# Altium schematic sheet Bus Management_B2.SchDoc
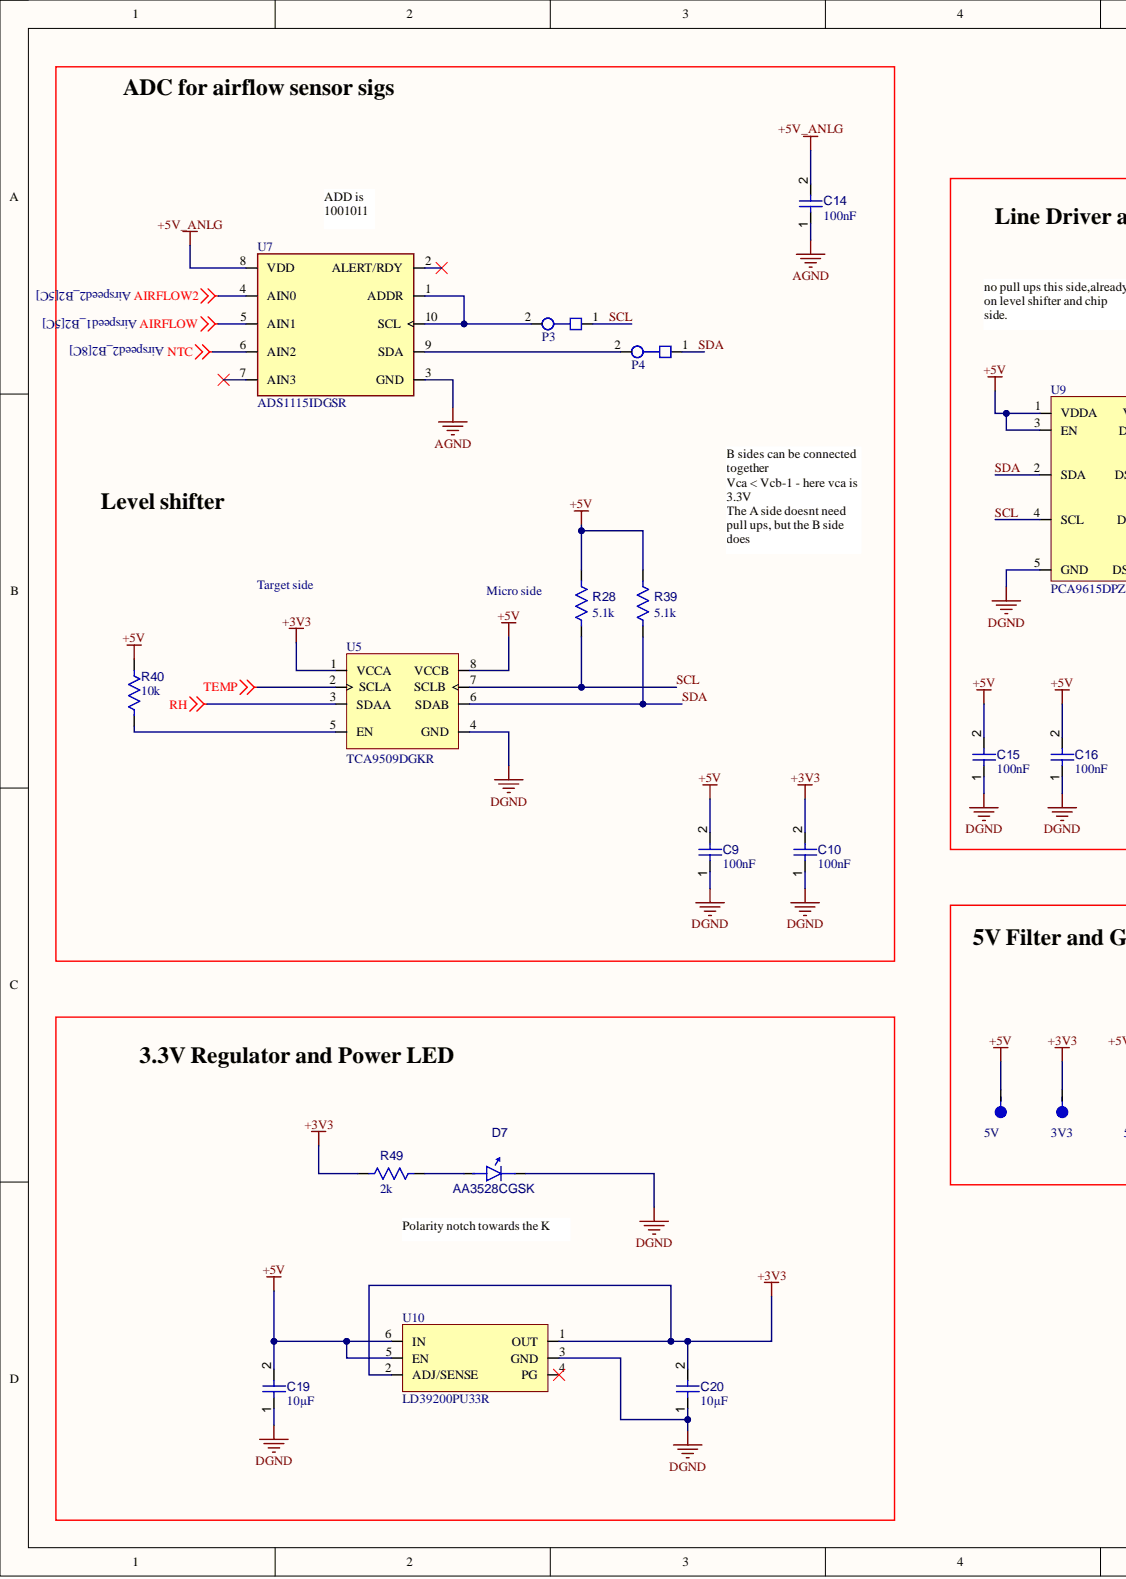
[diagram p0: Bus Management_B2.SchDoc, left]
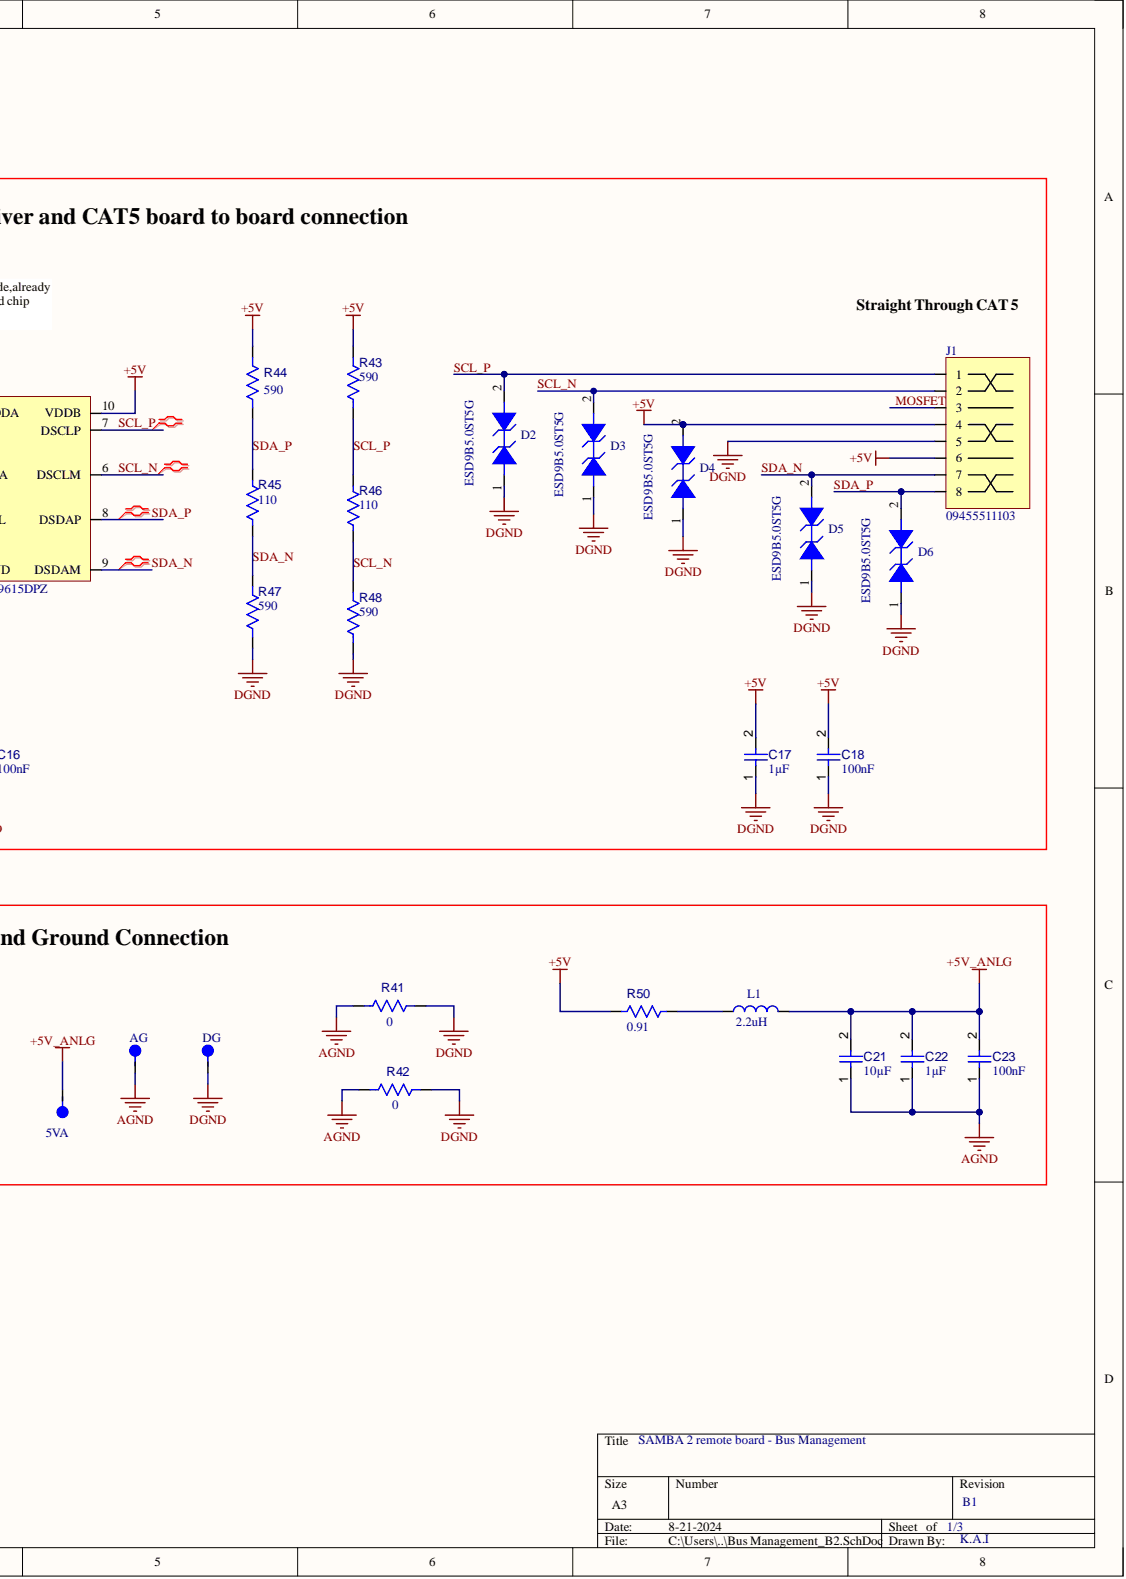
[diagram p1: Bus Management_B2.SchDoc, right]

## Parts
3V3  PH1-01-UA  [FP-PH1-01-UA-MFG]  (value not drawn)
5V  PH1-01-UA  [FP-PH1-01-UA-MFG]  (value not drawn)
5VA  PH1-01-UA  [FP-PH1-01-UA-MFG]  (value not drawn)
AG  PH1-01-UA  [FP-PH1-01-UA-MFG]  (value not drawn)
C9  value 100nF  [FP-CC0603-DA-MFG]  (hidden comment: CC0603KRX7R7BB104)
C10  value 100nF  [FP-CC0603-DA-MFG]  (hidden comment: CC0603KRX7R7BB104)
C14  value 100nF  [FP-CC0603-DA-MFG]  (hidden comment: CC0603KRX7R7BB104)
C15  value 100nF  [FP-CC0603-DA-MFG]  (hidden comment: CC0603KRX7R7BB104)
C16  value 100nF  [FP-CC0603-DA-MFG]  (hidden comment: CC0603KRX7R7BB104)
C17  value 1µF  [FP-CL10-IPC_C]  (hidden comment: CL10B105KA8NFNC)
C18  value 100nF  [FP-CC0603-DA-MFG]  (hidden comment: CC0603KRX7R7BB104)
C19  value 10µF  [FP-GRM188-0_15-MFG]  (hidden comment: GRM188R61A106ME69D)
C20  value 10µF  [FP-GRM188-0_15-MFG]  (hidden comment: GRM188R61A106ME69D)
C21  value 10µF  [FP-GRM188-0_15-MFG]  (hidden comment: GRM188R61A106ME69D)
C22  value 1µF  [FP-CL10-IPC_C]  (hidden comment: CL10B105KA8NFNC)
C23  value 100nF  [FP-CC0603-DA-MFG]  (hidden comment: CC0603KRX7R7BB104)
D2  ESD9B5.0ST5G  [ONSC-SOD-923-2-514AB-01_V]
D3  ESD9B5.0ST5G  [ONSC-SOD-923-2-514AB-01_V]
D4  ESD9B5.0ST5G  [ONSC-SOD-923-2-514AB-01_V]
D5  ESD9B5.0ST5G  [ONSC-SOD-923-2-514AB-01_V]
D6  ESD9B5.0ST5G  [ONSC-SOD-923-2-514AB-01_V]
D7  AA3528CGSK  [AA3528CGSK-Footprint-1]
DG  PH1-01-UA  [FP-PH1-01-UA-MFG]  (value not drawn)
J1  09455511103  [09455511103-Footprint-1]
L1  value 2.2uH  [INDC3225X06N]  (hidden comment: CC322522A-2R2K)
P3  PH1-02-UA  [PH1-02-UA-Footprint-1]  (value not drawn)
P4  PH1-02-UA  [PH1-02-UA-Footprint-1]  (value not drawn)
R28  value 5.1k  [0805]  (hidden comment: RC0805JR-075K1L)
R39  value 5.1k  [0805]  (hidden comment: RC0805JR-075K1L)
R40  value 10k  [FP-RC0603-0_55-MFG]  (hidden comment: RC0603FR-0710KL)
R41  value 0  [FP-RMCF0603-MFG]  (hidden comment: Resistor 0R +/- 5% 0603 100 mW)
R42  value 0  [FP-RMCF0603-MFG]  (hidden comment: Resistor 0R +/- 5% 0603 100 mW)
R43  value 590  [RESC1608X55X30LL15T15]  (hidden comment: ERJ-3EKF5900V)
R44  value 590  [RESC1608X55X30LL15T15]  (hidden comment: ERJ-3EKF5900V)
R45  value 110  [FP-RMCF0603-MFG]  (hidden comment: RMCF0603FT110R)
R46  value 110  [FP-RMCF0603-MFG]  (hidden comment: RMCF0603FT110R)
R47  value 590  [RESC1608X55X30LL15T15]  (hidden comment: ERJ-3EKF5900V)
R48  value 590  [RESC1608X55X30LL15T15]  (hidden comment: ERJ-3EKF5900V)
R49  value 2k  [IPC B 0603 RES]  (hidden comment: ERJ-3EKF2001V)
R50  value 0.91  [RESC2013X60X35ML10T20]  (hidden comment: RL0805FR-070R91L)
U5  TCA9509DGKR  [TSOP65P490X110-8N]
U7  ADS1115IDGSR  [FP-DGS0010A-MFG]
U9  PCA9615DPZ  [TSSOP50P490X110-10N]
U10  LD39200PU33R  [FP-DFN6-7946637-MFG]

## Nets
+3V3: 3V3.1 C10.2 C20.2 R49.2 U5.1(VCCA) U10.1(OUT) U10.2(ADJ/SENSE)
+5V: 5V.1 C9.2 C15.2 C16.2 C17.2 C18.2 C19.2 D4.2 J1.4 J1.6 R28.2 R39.2 R40.2 R43.2 R44.2 R50.1 U5.8(VCCB) U9.1(VDDA) U9.3(EN) U9.10(VDDB) U10.5(EN) U10.6(IN)
+5V_ANLG: 5VA.1 C14.2 C21.2 C22.2 C23.2 L1.2 U7.8(VDD)
AGND: AG.1 C14.1 C21.1 C22.1 C23.1 R41.1 R42.1 U7.3(GND)
AIRFLOW: U7.5(AIN1)
AIRFLOW2: U7.4(AIN0)
DGND: C9.1 C10.1 C15.1 C16.1 C17.1 C18.1 C19.1 C20.1 D2.1 D3.1 D4.1 D5.1 D6.1 D7.1 DG.1 J1.5 R41.2 R42.2 R47.1 R48.1 U5.4(GND) U9.5(GND) U10.3(GND)
NTC: U7.6(AIN2)
RH: U5.3(SDAA)
TEMP: U5.2(SCLA)
MOSFET: J1.3
SCL: P3.1 R28.1 U5.7(SCLB) U9.4(SCL)
SCL_N: D3.2 J1.2 R46.1 R48.2 U9.6(DSCLM)
SCL_P: D2.2 J1.1 R43.1 R46.2 U9.7(DSCLP)
SDA: P4.1 R39.1 U5.6(SDAB) U9.2(SDA)
SDA_N: D5.2 J1.7 R45.1 R47.2 U9.9(DSDAM)
SDA_P: D6.2 J1.8 R44.1 R45.2 U9.8(DSDAP)
NetD7_2: D7.2 R49.1
NetL1_1: L1.1 R50.2
NetP3_2: P3.2 U7.1(ADDR) U7.10(SCL)
NetP4_2: P4.2 U7.9(SDA)
NetR40_1: R40.1 U5.5(EN)

Not connected: U7 2(ALERT/RDY) 7(AIN3); U10 4(PG)

## Library data of the parts
3V3 5V 5VA AG DG = CMP-002-00083-1: Conn Unshrouded Header HDR 1 POS 2.54mm Solder ST Thru-Hole Bag; Component Kind: Standard; Library Name: University of Sydney
C9 C10 C14-C16 C18 C23 = CMP-001-00099-1: Ceramic Capacitor, Multilayer, Ceramic, 16V, 10% +Tol, 10% -Tol, X7R, 15% TC, 0.1uF, Surface Mount, 0603; Capacitor Type: Ceramic; Case/Package: 0603; Component Kind: Standard; Max Operating Temperature: 125°C; Min Operating Temperature: -55°C; Mounting Technology: Surface Mount; Pins: 2; RoHS Compliant: Yes; Library Name: University of Sydney
C17 C22 = CMP-001-00098-1: Ceramic Capacitor, Multilayer, Ceramic, 25V, 10% +Tol, 10% -Tol, X7R, 15% TC, 1uF, Surface Mount, 0603; Capacitor Type: Ceramic; Case/Package: 0603; Component Kind: Standard; Max Operating Temperature: 125°C; Min Operating Temperature: -55°C; Mounting Technology: Surface Mount; Pins: 2; RoHS Compliant: Yes; Library Name: University of Sydney
C19-C21 = CMP-001-00097-1: Ceramic Capacitor, Multilayer, Ceramic, 10V, 20% +Tol, 20% -Tol, X5R, 15% TC, 10uF, Surface Mount, 0603; Capacitor Type: Ceramic; Case/Package: 0603; Component Kind: Standard; Max Operating Temperature: 85°C; Min Operating Temperature: -55°C; Mounting Technology: Surface Mount; Pins: 2; RoHS Compliant: Yes; Library Name: University of Sydney
D2-D6 = CMP-004-00032-1: Trans Voltage Suppressor Diode, 5V V(RWM), Bidirectional, 1 Element, Silicon; Component Kind: Standard; Max Operating Temperature: 150°C; Min Operating Temperature: -55°C; Pins: 2; RoHS Compliant: Yes; Library Name: University of Sydney
D7 = CMP-004-00031-3: Single Color LED, Green, Water Clear, 2.4mm; Forward Current: 30mA; Colour: Green; Component Kind: Standard; Max Operating Temperature: 85°C; Min Operating Temperature: -40°C; Mounting Technology: Surface Mount; Pins: 2; RoHS Compliant: Yes; Library Name: University of Sydney
J1 = CMP-002-00071-6: Telecom and Datacom Connector, 8 Contact(s), Female, Straight, Solder Terminal, Locking, Jack; Component Kind: Standard; Connector Type: TELECOM AND DATACOM CONNECTOR; Max Operating Temperature: 70°C; Min Operating Temperature: -40°C; Mounting Technology: Board; RoHS Compliant: Yes; Library Name: University of Sydney
L1 = CMP-006-00022-2: General Purpose Inductor, 2.2uH, 10%, 1 Element, Ferrite-Core, SMD, 1110; Component Kind: Standard; RoHS Compliant: Yes; Library Name: University of Sydney
P3 P4 = CMP-002-00078-1: Conn Unshrouded Header HDR 2 POS 2.54mm Solder ST Thru-Hole Bag; Component Kind: Standard; Library Name: University of Sydney
R28 R39 = CMP-009-00191-2: Fixed Resistor, Metal Glaze/thick Film, 0.125W, 5100ohm, 150V, 5% +/-Tol, 100ppm/Cel, Surface Mount, 0805; Power: 125mW; Case/Package: 0805; Component Kind: Standard; Max Operating Temperature: 155°C; Min Operating Temperature: -55°C; Mounting Technology: Surface Mount; Pins: 2; RoHS Compliant: Yes; Tolerance: 5%; Library Name: University of Sydney
R40 = CMP-009-00204-1: Fixed Resistor, Metal Glaze/thick Film, 0.1W, 10000ohm, 75V, 1% +/-Tol, 100ppm/Cel, Surface Mount, 0603; Power: 100mW; Case/Package: 0603; Component Kind: Standard; Max Operating Temperature: 155°C; Min Operating Temperature: -55°C; Mounting Technology: Surface Mount; Pins: 2; RoHS Compliant: Yes; Tolerance: 1%; Library Name: University of Sydney
R41 R42 = CMP-009-00006-7: Chip Resistor, 0 Ohm, 0.1 W, -55 to 155 degC, 0603 (1608 Metric); Power: 100mW; Voltage Rating: 50V; Case/Package: 0603; Component Kind: Standard; Max Operating Temperature: 155°C; Min Operating Temperature: -55°C; Mounting Technology: SM; Pins: 2; RoHS Compliant: True; Tolerance: 5%; Library Name: University of Sydney
R43 R44 R47 R48 = CMP-009-00193-2: Fixed Resistor, Metal Glaze/thick Film, 0.1W, 590ohm, 75V, 1% +/-Tol, 100ppm/Cel, Surface Mount, 0603; Power: 100mW; Case/Package: 0603; Component Kind: Standard; Max Operating Temperature: 155°C; Min Operating Temperature: -55°C; Mounting Technology: Surface Mount; Pins: 2; RoHS Compliant: Yes; Tolerance: 1%; Library Name: University of Sydney
R45 R46 = CMP-009-00194-3: Fixed Resistor, Metal Glaze/thick Film, 0.1W, 110ohm, 75V, 1% +/-Tol, 100ppm/Cel, Surface Mount, 0603; Power: 100mW; Case/Package: 0603; Component Kind: Standard; Max Operating Temperature: 155°C; Min Operating Temperature: -55°C; Mounting Technology: Surface Mount; Pins: 2; RoHS Compliant: Yes; Tolerance: 1%; Library Name: University of Sydney
R49 = CMP-009-00207-1: Fixed Resistor, Metal Glaze/thick Film, 0.1W, 2000ohm, 75V, 1% +/-Tol, 100ppm/Cel, Surface Mount, 0603; Power: 100mW; Case/Package: 0603; Component Kind: Standard; Max Operating Temperature: 155°C; Min Operating Temperature: -55°C; Mounting Technology: Surface Mount; Pins: 2; RoHS Compliant: Yes; Tolerance: 1%; Library Name: University of Sydney
R50 = CMP-009-00195-2: Fixed Resistor, Metal Glaze/thick Film, 0.125W, 0.91ohm, 1% +/-Tol, -200,200ppm/Cel, 0805; Power: 125mW; Case/Package: 0805; Component Kind: Standard; Max Operating Temperature: 125°C; Min Operating Temperature: -55°C; Pins: 2; RoHS Compliant: Yes; Tolerance: 1%; Library Name: University of Sydney
U5 = CMP-00024-00006-2: Interface Circuit, PDSO8; Case/Package: TSSOP; Component Kind: Standard; Max Operating Temperature: 85°C; Min Operating Temperature: -40°C; Pins: 8; RoHS Compliant: Yes; Library Name: University of Sydney
U7 = CMP-015-00008-1: ADC, Delta-Sigma, 16-Bit, 1 Func, 4 Channel, Serial Access, PDSO10; Nominal Supply Voltage: 3.3V; Case/Package: TSSOP; Component Kind: Standard; Max Operating Temperature: 125°C; Min Operating Temperature: -40°C; Pins: 10; RoHS Compliant: Yes; Library Name: University of Sydney
U9 = CMP-00024-00007-3: IC Redriver I2C 2CH 10TSSOP; Component Kind: Standard; Library Name: University of Sydney
U10 = CMP-019-00050-2: Fixed Positive LDO Regulator, 3.3V, 0.25V Dropout; Max Supply Voltage: 6V; Case/Package: HVSON; Component Kind: Standard; Pins: 6; RoHS Compliant: Yes; Library Name: University of Sydney
